# Altium schematic sheet Main Hull Power Board.SchDoc("Main Hull Power Board")
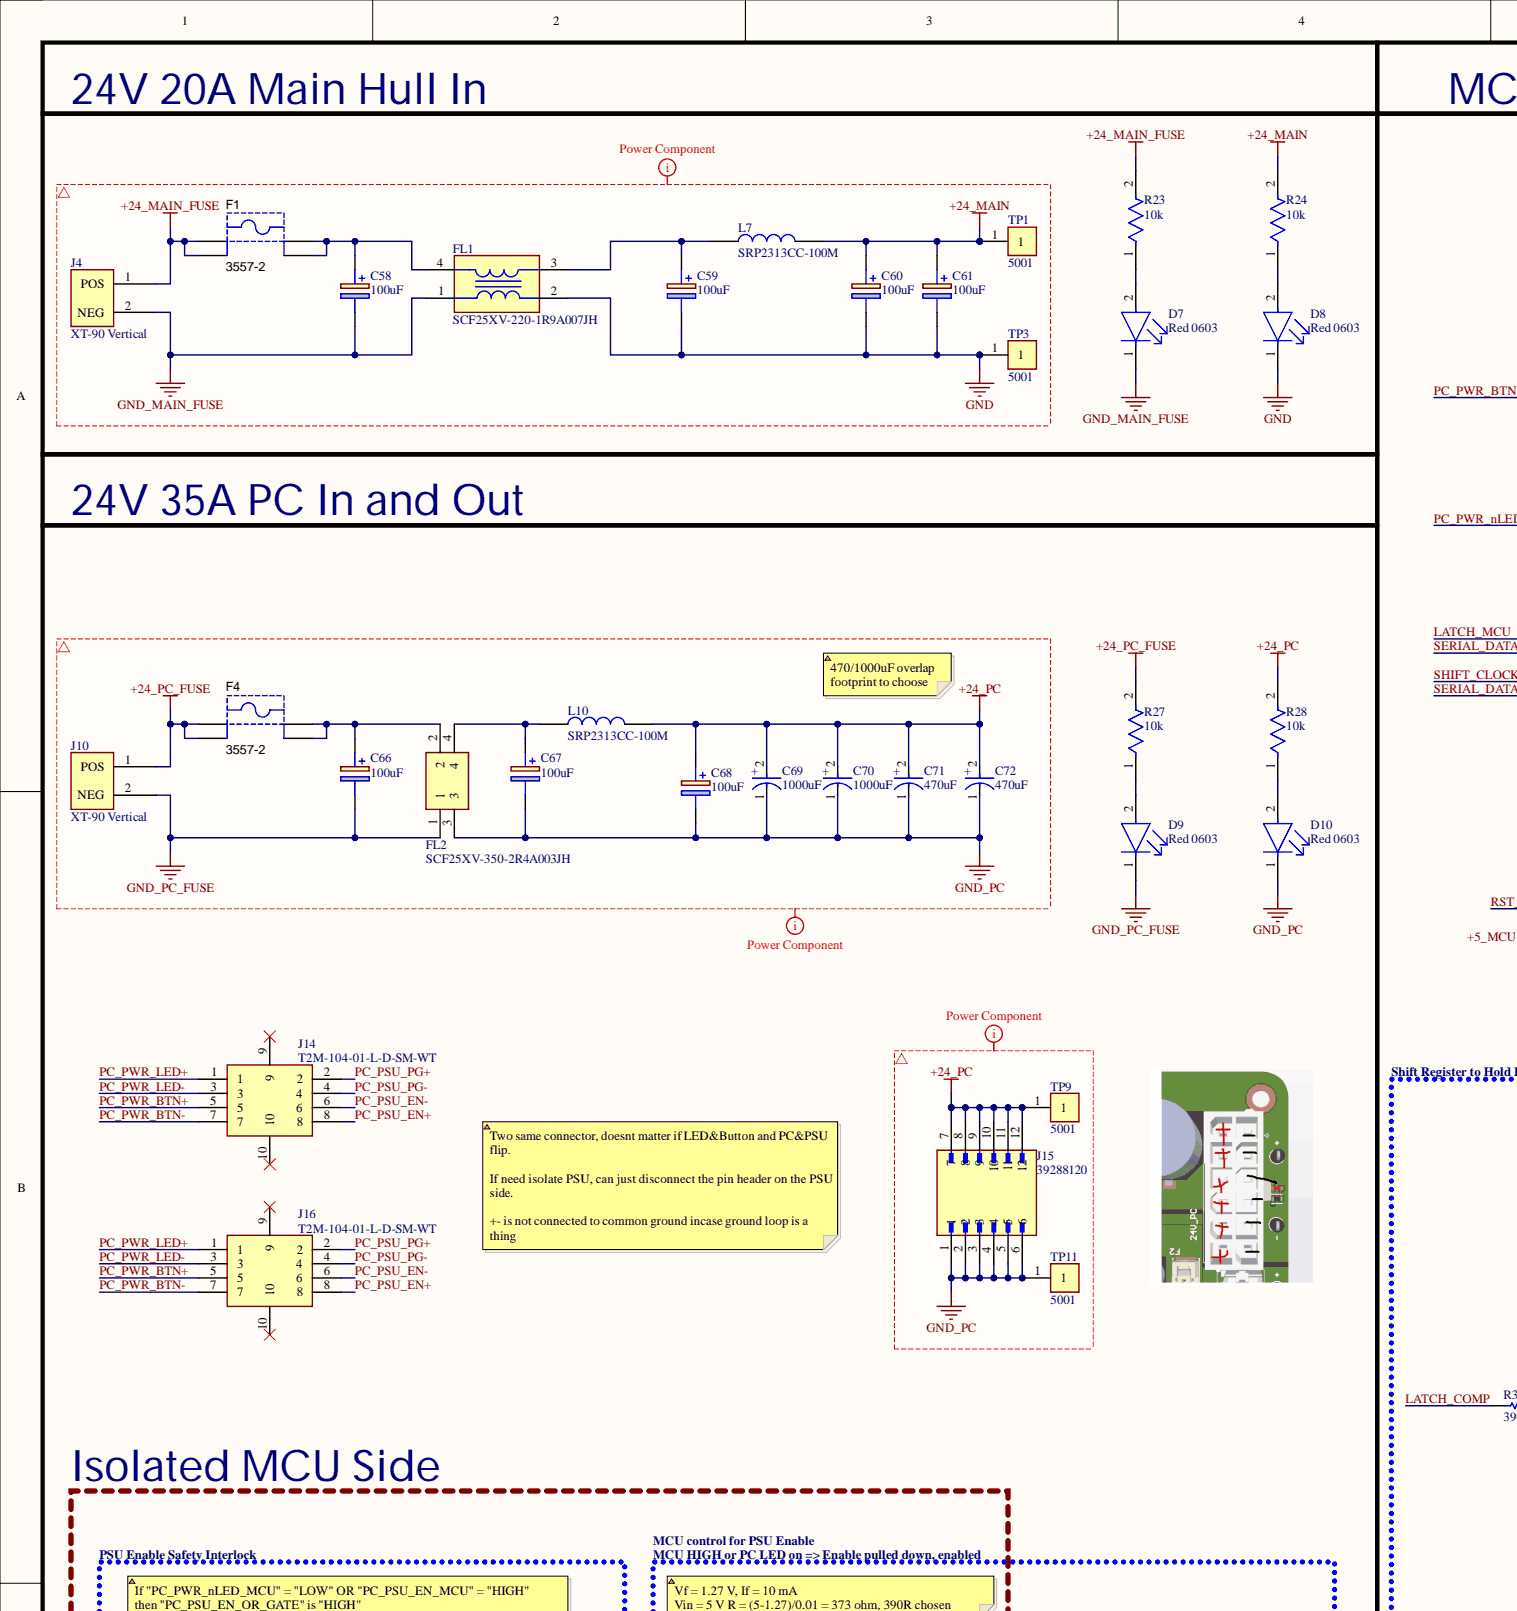
[diagram p0: Main Hull Power Board.SchDoc, top left]
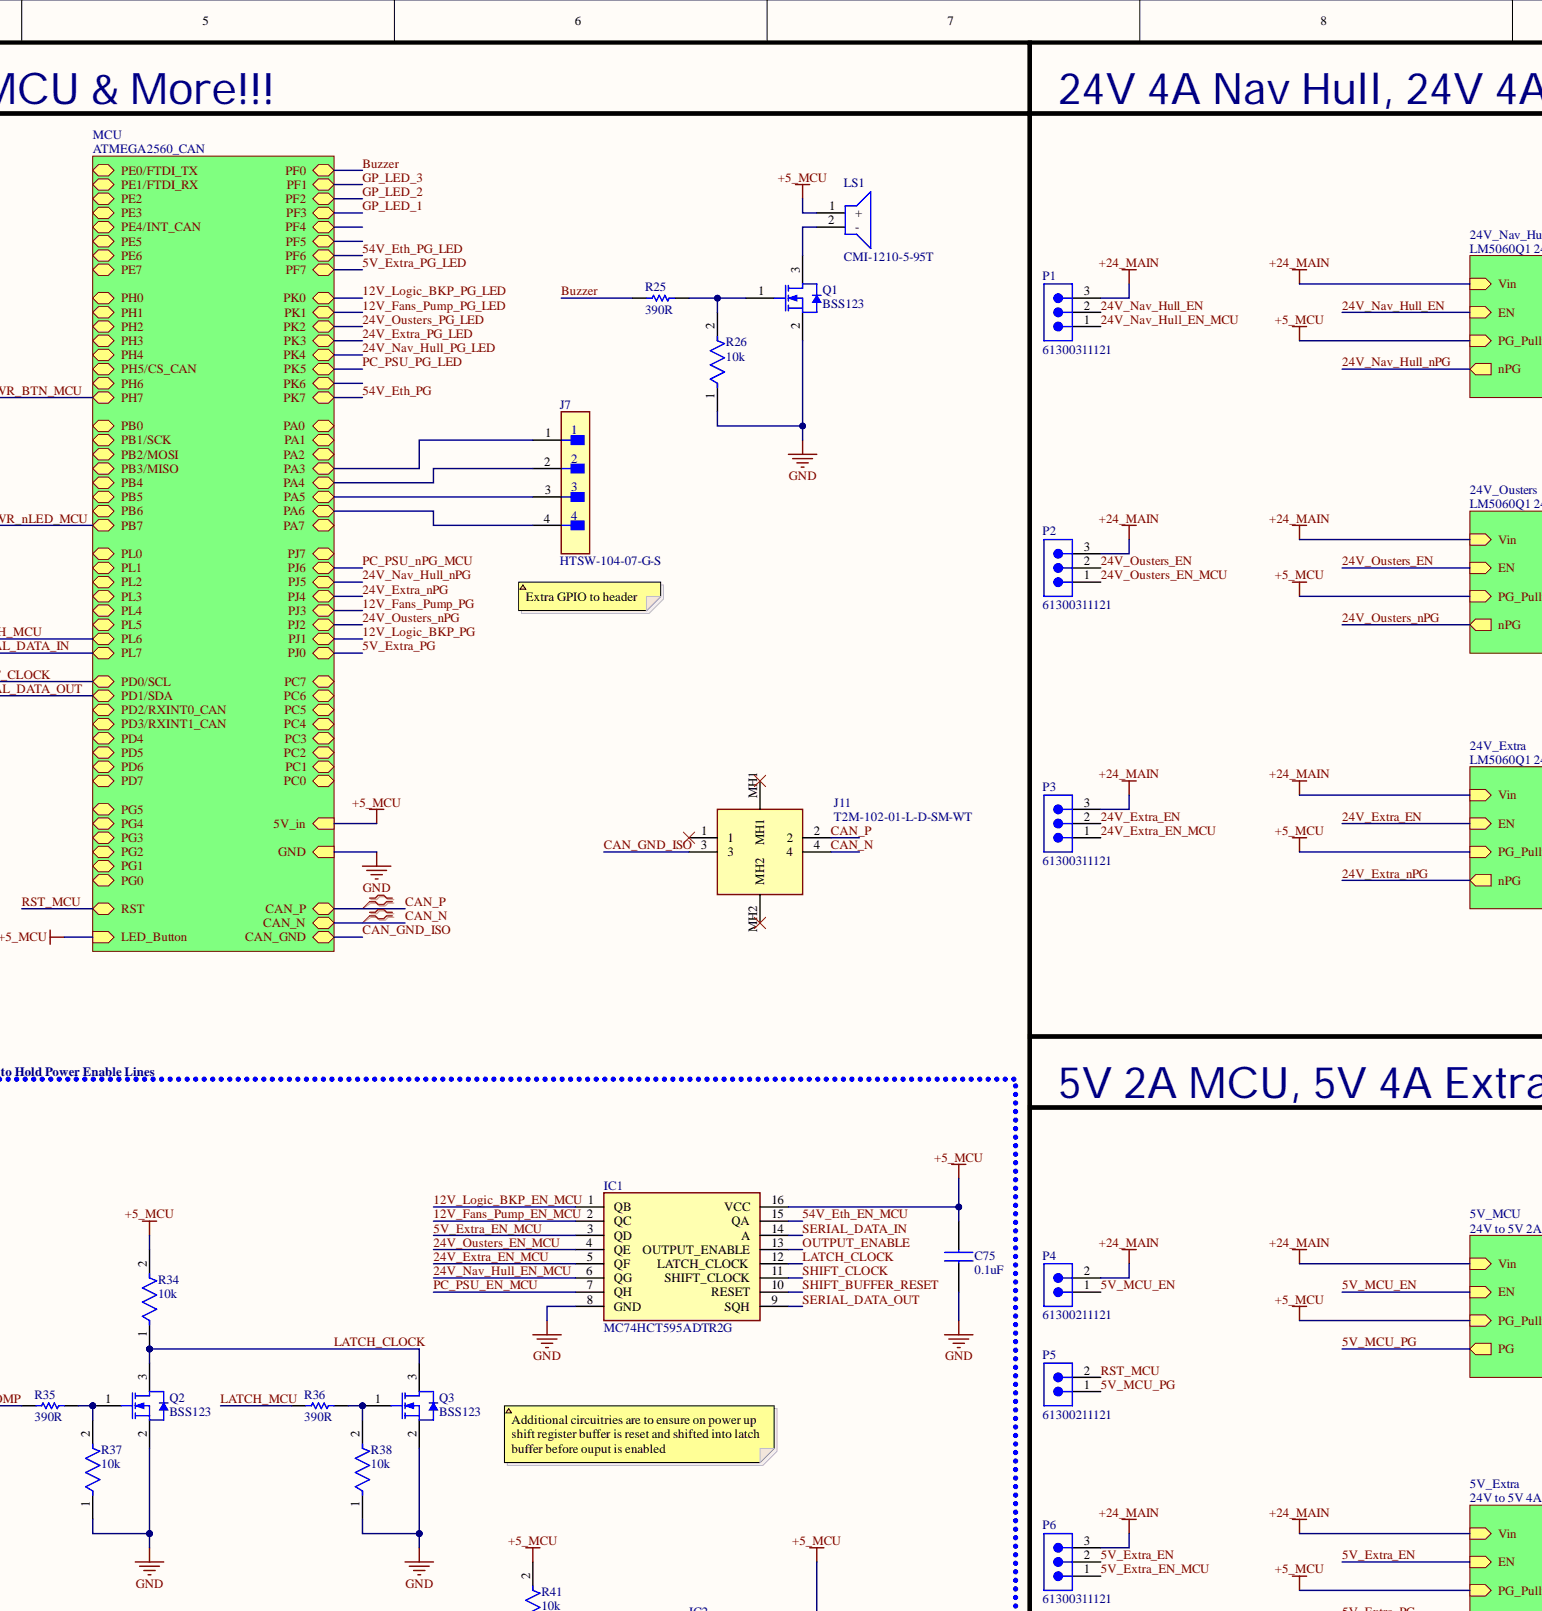
[diagram p1: Main Hull Power Board.SchDoc, top centre]
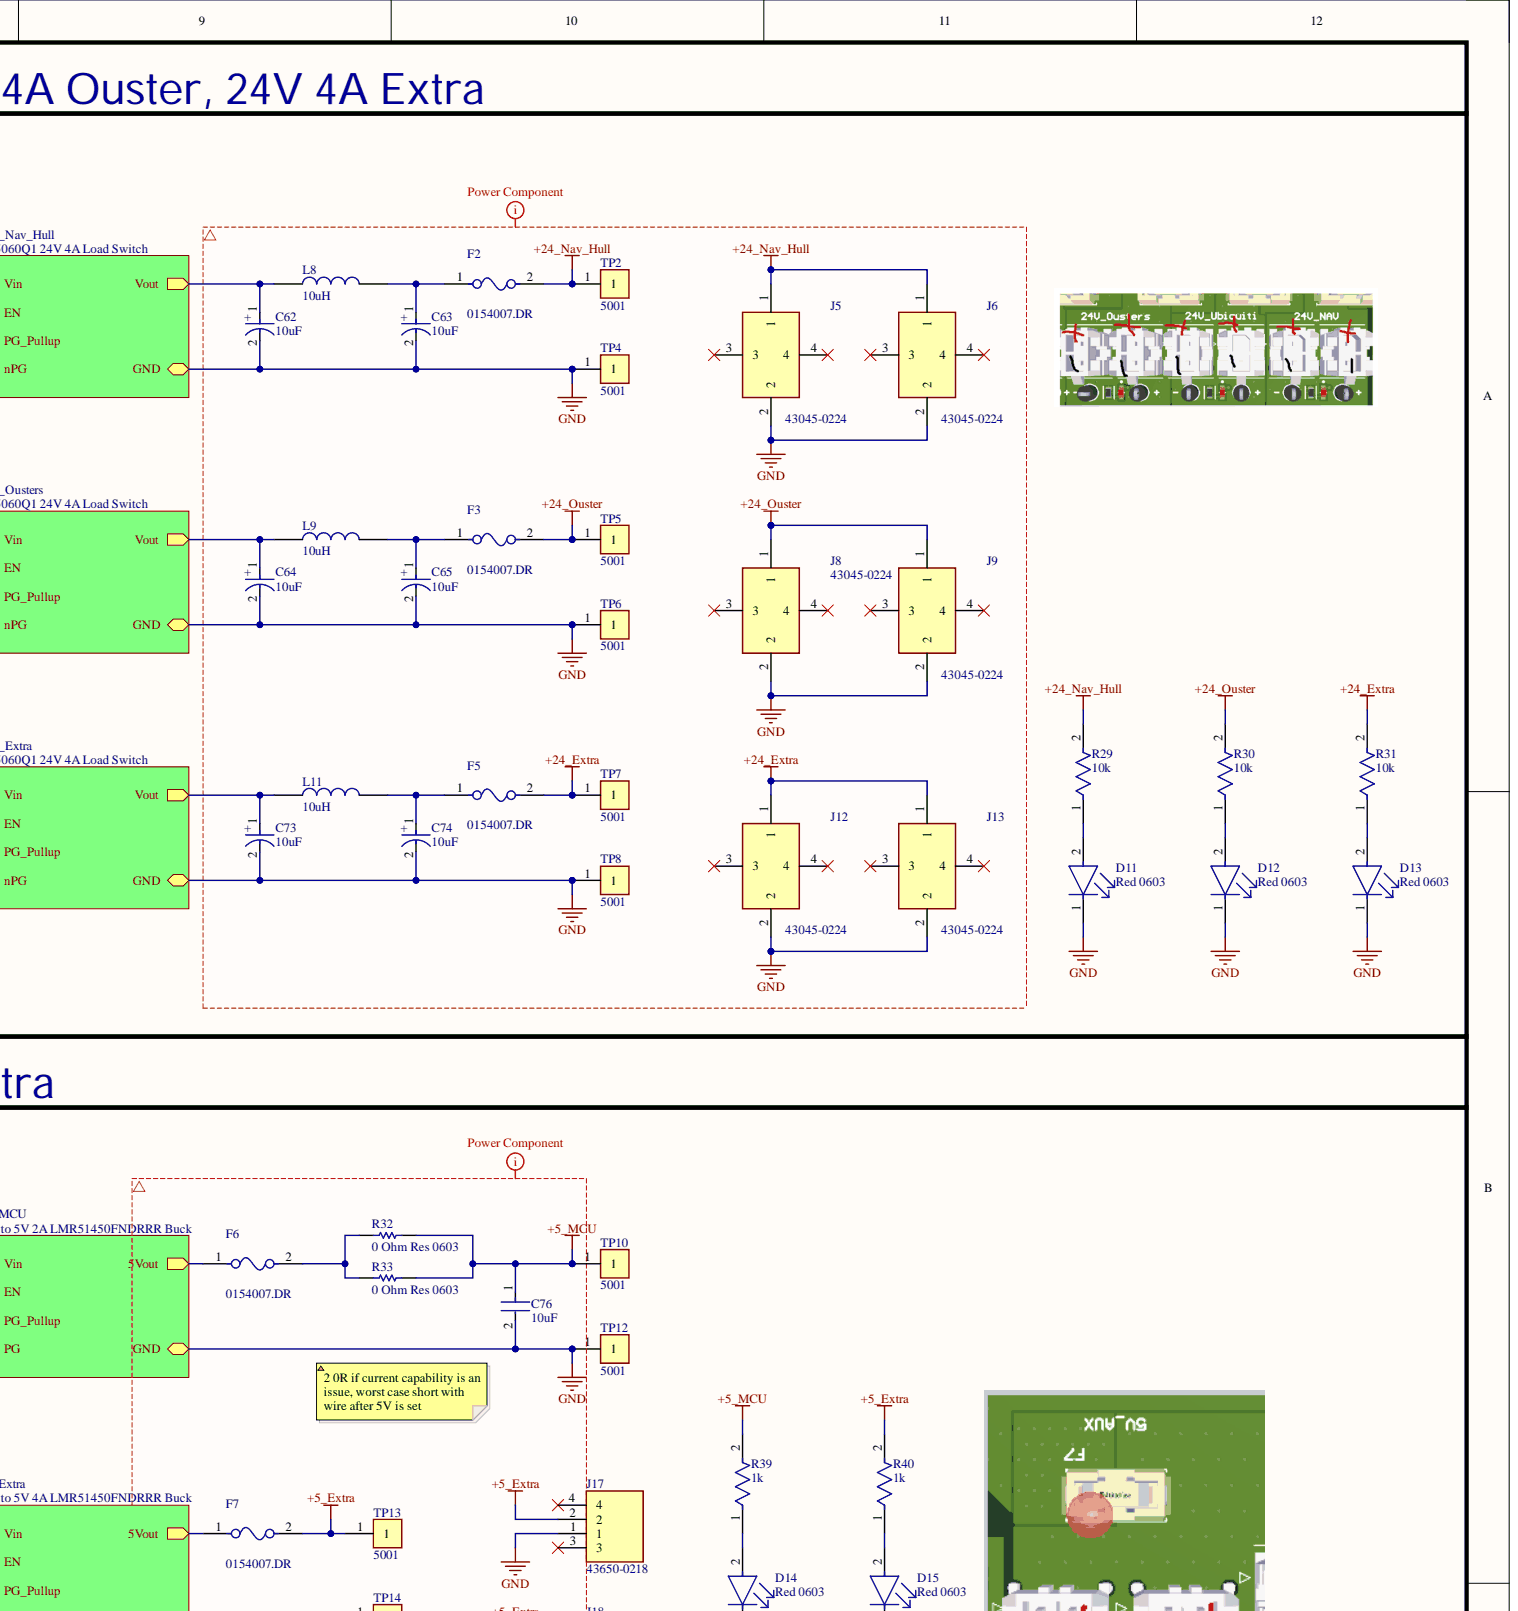
[diagram p2: Main Hull Power Board.SchDoc, top right]
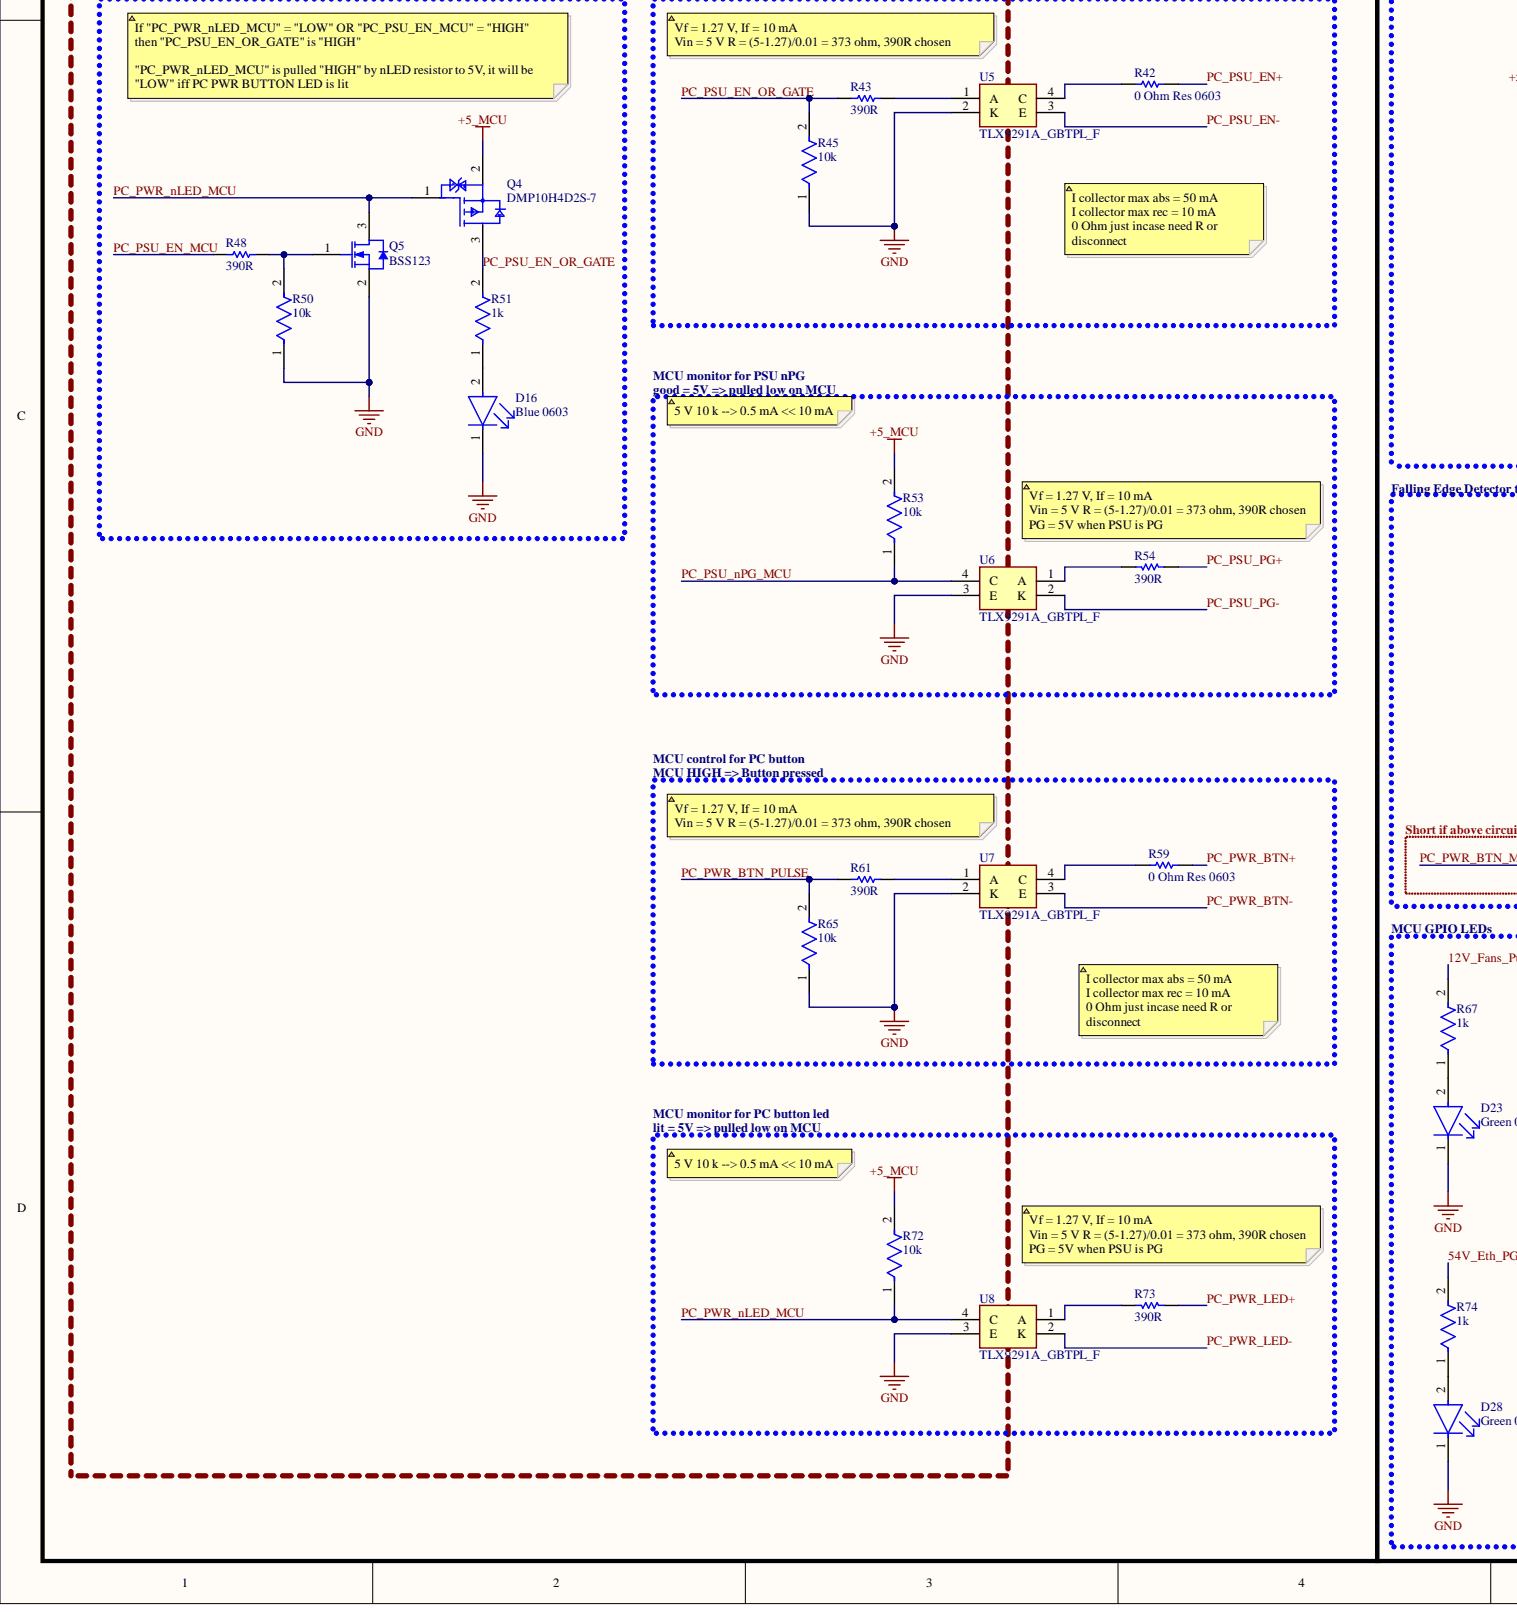
[diagram p3: Main Hull Power Board.SchDoc, bottom left]
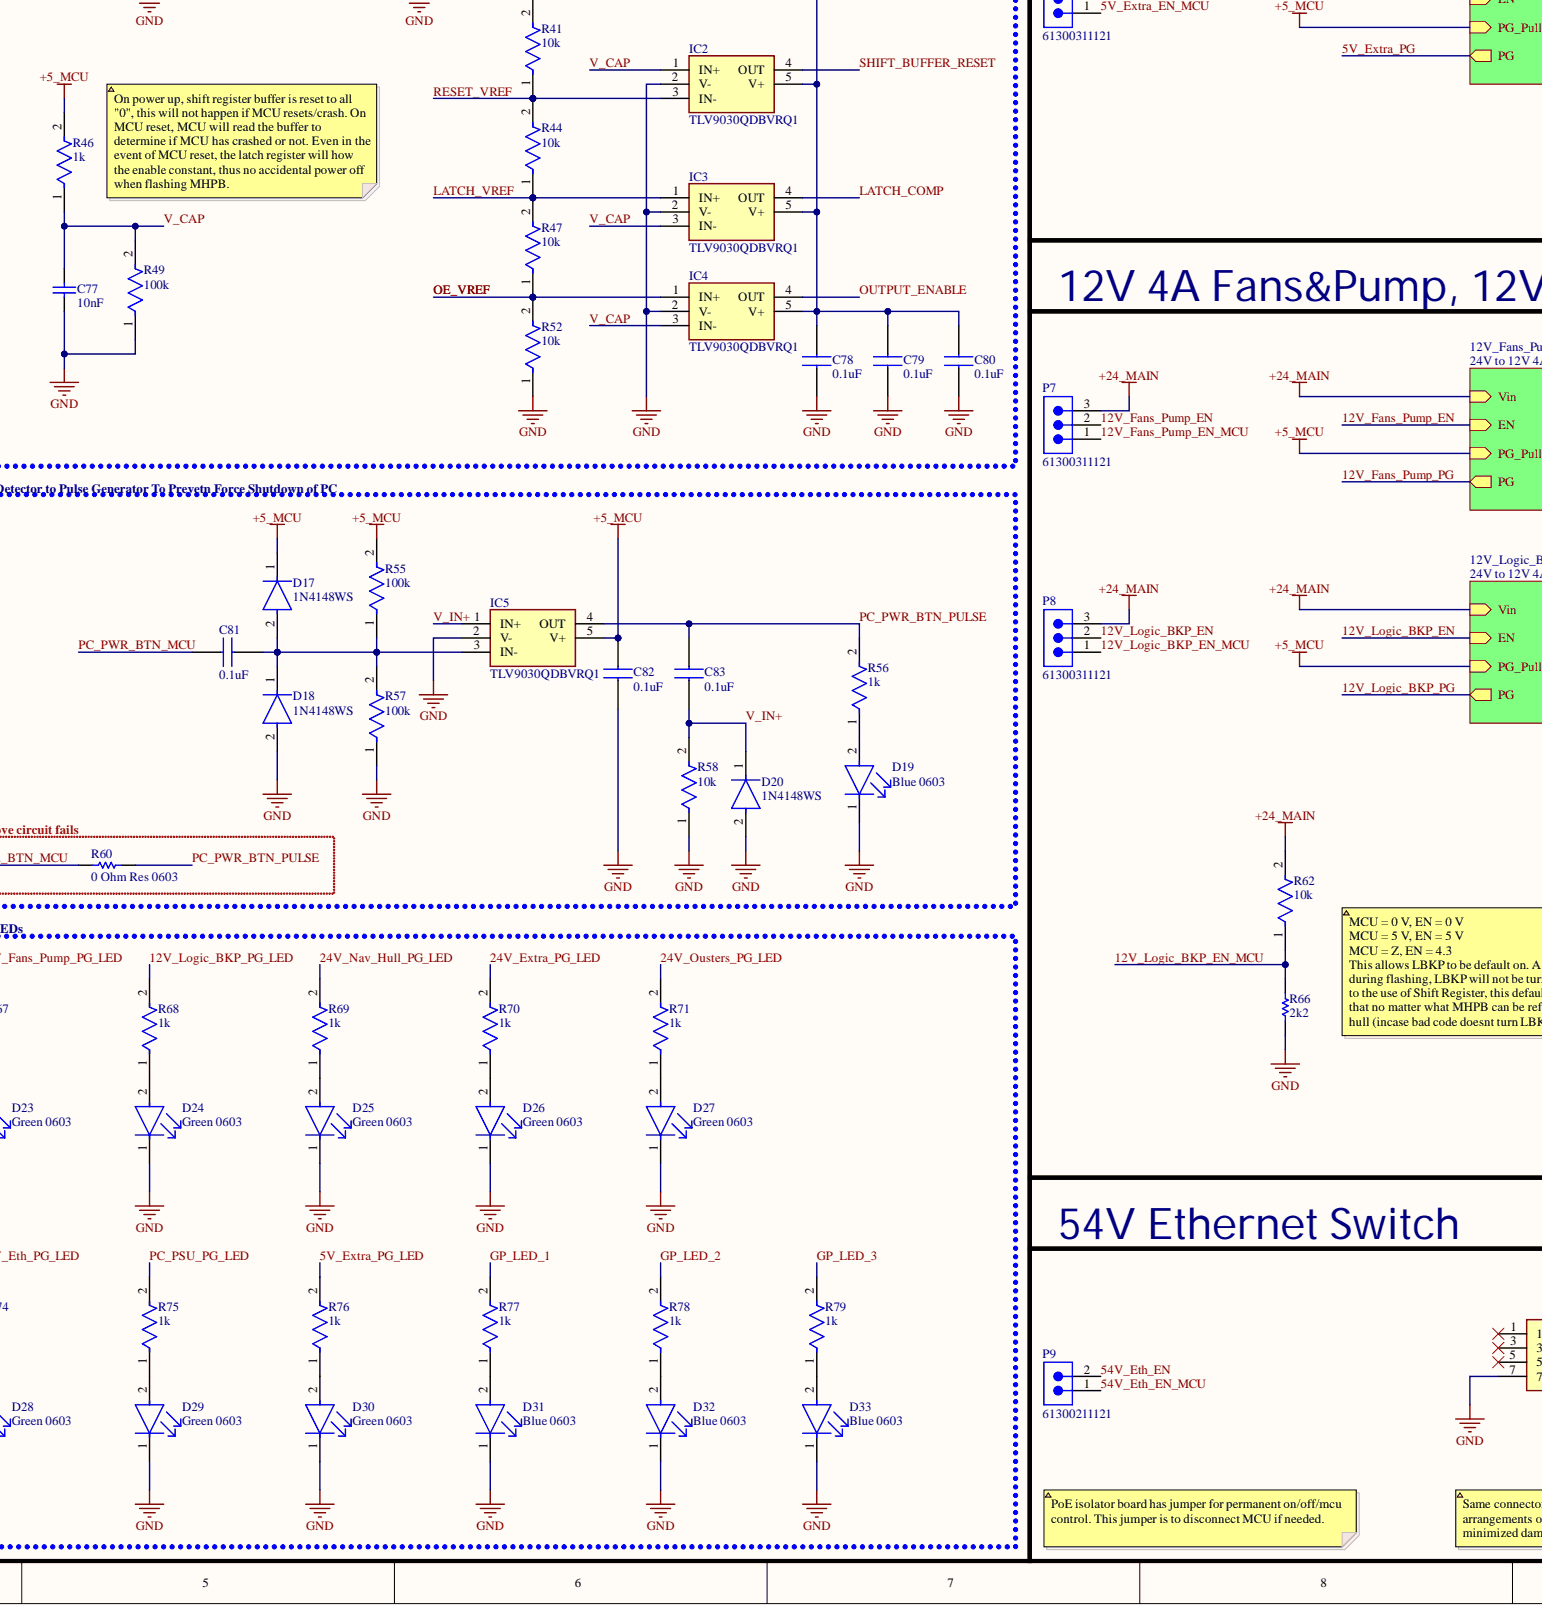
[diagram p4: Main Hull Power Board.SchDoc, bottom centre]
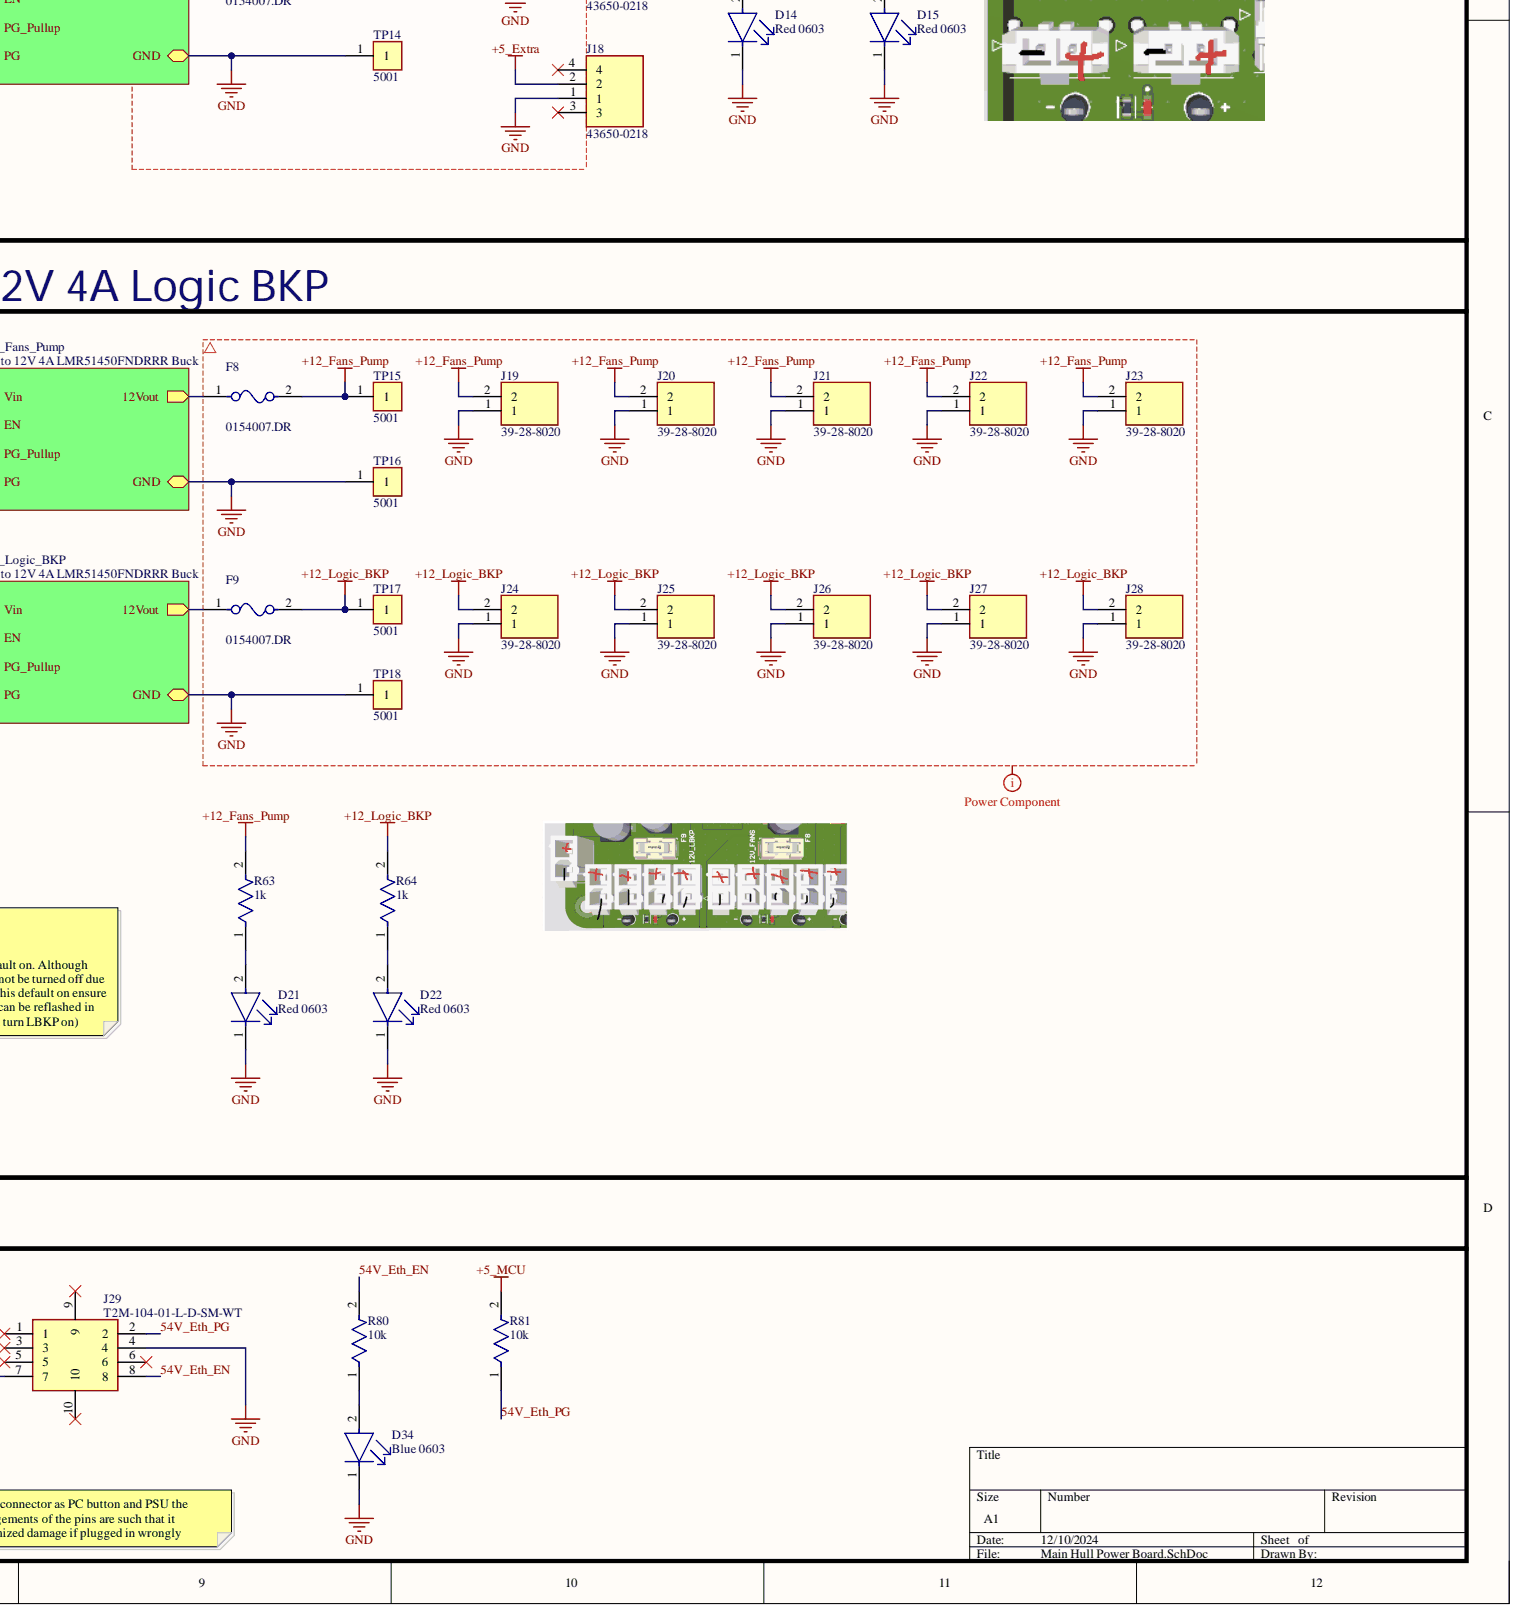
[diagram p5: Main Hull Power Board.SchDoc, bottom right]

## Parts
C58  100uF
C59  100uF
C60  100uF
C61  100uF
C62  10uF
C63  10uF
C64  10uF
C65  10uF
C66  100uF
C67  100uF
C68  100uF
C69  1000uF
C70  1000uF
C71  470uF
C72  470uF
C73  10uF
C74  10uF
C75  0.1uF
C76  10uF
C77  10nF
C78  0.1uF
C79  0.1uF
C80  0.1uF
C81  0.1uF
C82  0.1uF
C83  0.1uF
D7  Red 0603  Case Code (Imperial): 0603  Color: Red
D8  Red 0603  Case Code (Imperial): 0603  Color: Red
D9  Red 0603  Case Code (Imperial): 0603  Color: Red
D10  Red 0603  Case Code (Imperial): 0603  Color: Red
D11  Red 0603  Case Code (Imperial): 0603  Color: Red
D12  Red 0603  Case Code (Imperial): 0603  Color: Red
D13  Red 0603  Case Code (Imperial): 0603  Color: Red
D14  Red 0603  Case Code (Imperial): 0603  Color: Red
D15  Red 0603  Case Code (Imperial): 0603  Color: Red
D16  Blue 0603  Case Code (Imperial): 0603  Color: Blue
D17  1N4148WS
D18  1N4148WS
D19  Blue 0603  Case Code (Imperial): 0603  Color: Blue
D20  1N4148WS
D21  Red 0603  Case Code (Imperial): 0603  Color: Red
D22  Red 0603  Case Code (Imperial): 0603  Color: Red
D23  Green 0603  Case Code (Imperial): 0603  Color: Green
D24  Green 0603  Case Code (Imperial): 0603  Color: Green
D25  Green 0603  Case Code (Imperial): 0603  Color: Green
D26  Green 0603  Case Code (Imperial): 0603  Color: Green
D27  Green 0603  Case Code (Imperial): 0603  Color: Green
D28  Green 0603  Case Code (Imperial): 0603  Color: Green
D29  Green 0603  Case Code (Imperial): 0603  Color: Green
D30  Green 0603  Case Code (Imperial): 0603  Color: Green
D31  Blue 0603  Case Code (Imperial): 0603  Color: Blue
D32  Blue 0603  Case Code (Imperial): 0603  Color: Blue
D33  Blue 0603  Case Code (Imperial): 0603  Color: Blue
D34  Blue 0603  Case Code (Imperial): 0603  Color: Blue
F1  3557-2
F2  0154007.DR
F3  0154007.DR
F4  3557-2
F5  0154007.DR
F6  0154007.DR
F7  0154007.DR
F8  0154007.DR
F9  0154007.DR
FL1  SCF25XV-220-1R9A007JH
FL2  SCF25XV-350-2R4A003JH
IC1  MC74HCT595ADTR2G
IC2  TLV9030QDBVRQ1
IC3  TLV9030QDBVRQ1
IC4  TLV9030QDBVRQ1
IC5  TLV9030QDBVRQ1
J4  XT-90 Vertical
J5  43045-0224
J6  43045-0224
J7  HTSW-104-07-G-S
J8  43045-0224
J9  43045-0224
J10  XT-90 Vertical
J11  T2M-102-01-L-D-SM-WT
J12  43045-0224
J13  43045-0224
J14  T2M-104-01-L-D-SM-WT
J15  39288120
J16  T2M-104-01-L-D-SM-WT
J17  43650-0218
J18  43650-0218
J19  39-28-8020
J20  39-28-8020
J21  39-28-8020
J22  39-28-8020
J23  39-28-8020
J24  39-28-8020
J25  39-28-8020
J26  39-28-8020
J27  39-28-8020
J28  39-28-8020
J29  T2M-104-01-L-D-SM-WT
L7  SRP2313CC-100M
L8  10uH
L9  10uH
L10  SRP2313CC-100M
L11  10uH
LS1  CMI-1210-5-95T
P1  61300311121
P2  61300311121
P3  61300311121
P4  61300211121
P5  61300211121
P6  61300311121
P7  61300311121
P8  61300311121
P9  61300211121
Q1  BSS123
Q2  BSS123
Q3  BSS123
Q4  DMP10H4D2S-7
Q5  BSS123
R23  10k
R24  10k
R25  390R
R26  10k
R27  10k
R28  10k
R29  10k
R30  10k
R31  10k
R32  0 Ohm Res 0603  Case Code (Imperial): 0603
R33  0 Ohm Res 0603  Case Code (Imperial): 0603
R34  10k
R35  390R
R36  390R
R37  10k
R38  10k
R39  1k
R40  1k
R41  10k
R42  0 Ohm Res 0603  Case Code (Imperial): 0603
R43  390R
R44  10k
R45  10k
R46  1k
R47  10k
R48  390R
R49  100k
R50  10k
R51  1k
R52  10k
R53  10k
R54  390R
R55  100k
R56  1k
R57  100k
R58  10k
R59  0 Ohm Res 0603  Case Code (Imperial): 0603
R60  0 Ohm Res 0603  Case Code (Imperial): 0603
R61  390R
R62  10k
R63  1k
R64  1k
R65  10k
R66  2k2
R67  1k
R68  1k
R69  1k
R70  1k
R71  1k
R72  10k
R73  390R
R74  1k
R75  1k
R76  1k
R77  1k
R78  1k
R79  1k
R80  10k
R81  10k
TP1  5001
TP2  5001
TP3  5001
TP4  5001
TP5  5001
TP6  5001
TP7  5001
TP8  5001
TP9  5001
TP10  5001
TP11  5001
TP12  5001
TP13  5001
TP14  5001
TP15  5001
TP16  5001
TP17  5001
TP18  5001
U5  TLX9291A_GBTPL_F
U6  TLX9291A_GBTPL_F
U7  TLX9291A_GBTPL_F
U8  TLX9291A_GBTPL_F

## Nets
GND: C59.2 C60.2 C61.2 C62.2 C63.2 C64.2 C65.2 C73.2 C74.2 C75.1 C76.2 C77.1 C78.2 C79.2 C80.2 C82.2 D8.1 D11.1 D12.1 D13.1 D14.1 D15.1 D16.1 D18.2 D19.1 D20.2 D21.1 D22.1 D23.1 D24.1 D25.1 D26.1 D27.1 D28.1 D29.1 D30.1 D31.1 D32.1 D33.1 D34.1 FL1.2 IC1.8(GND) IC2.2(V-) IC3.2(V-) IC4.2(V-) IC5.2(V-) J5.2 J6.2 J8.2 J9.2 J12.2 J13.2 J17.1 J18.1 J19.1 J20.1 J21.1 J22.1 J23.1 J24.1 J25.1 J26.1 J27.1 J28.1 J29.4 J29.7 Q1.2 Q2.2 Q3.2 Q5.2 R26.1 R37.1 R38.1 R45.1 R49.1 R50.1 R52.1 R57.1 R58.1 R65.1 R66.1 TP3.1 TP4.1 TP6.1 TP8.1 TP12.1 TP14.1 TP16.1 TP18.1 U5.2(K) U6.3(E) U7.2(K) U8.3(E)
GND_MAIN_FUSE: C58.2 D7.1 FL1.1 J4.2
GND_PC: C67.2 C68.2 C69.1 C70.1 C71.1 C72.1 D10.1 FL2.3 J15.1 J15.2 J15.3 J15.4 J15.5 J15.6 TP11.1
GND_PC_FUSE: C66.2 D9.1 FL2.1 J10.2
5V_Extra_EN: C75.2 C76.1 C78.1 C79.1 C80.1 C82.1 D17.1 F7.2 IC1.16(VCC) IC2.5(V+) IC3.5(V+) IC4.5(V+) IC5.5(V+) J17.2 J18.2 LS1.1 P6.2 Q4.2 R32.2 R33.2 R34.2 R39.2 R40.2 R41.2 R46.2 R53.2 R55.2 R72.2 R81.2 TP10.1 TP13.1
5V_Extra_EN_MCU: IC1.3(QD) P6.1
5V_Extra_PG_LED: R76.2
5V_MCU_EN: P4.1
5V_MCU_PG: P5.1
12V_Fans_Pump_EN: F8.2 F9.2 J19.2 J20.2 J21.2 J22.2 J23.2 J24.2 J25.2 J26.2 J27.2 J28.2 P7.2 R63.2 R64.2 TP15.1 TP17.1
12V_Fans_Pump_EN_MCU: IC1.2(QC) P7.1
12V_Fans_Pump_PG_LED: R67.2
12V_Logic_BKP_EN: P8.2
12V_Logic_BKP_EN_MCU: IC1.1(QB) P8.1 R62.1 R66.2
12V_Logic_BKP_PG_LED: R68.2
24V_Extra_EN: C60.1 C61.1 C68.1 C69.2 C70.2 C71.2 C72.2 F1.1 F1.2 F2.2 F3.2 F4.1 F4.2 F5.2 J4.1 J5.1 J6.1 J8.1 J9.1 J10.1 J12.1 J13.1 J15.7 J15.8 J15.9 J15.10 J15.11 J15.12 L7.2 L10.2 P1.3 P2.3 P3.2 P3.3 P4.2 P6.3 P7.3 P8.3 R23.2 R24.2 R27.2 R28.2 R29.2 R30.2 R31.2 R62.2 TP1.1 TP2.1 TP5.1 TP7.1 TP9.1
24V_Extra_EN_MCU: IC1.5(QF) P3.1
24V_Extra_PG_LED: R70.2
24V_Nav_Hull_EN: P1.2
24V_Nav_Hull_EN_MCU: IC1.6(QG) P1.1
24V_Nav_Hull_PG_LED: R69.2
24V_Ousters_EN: P2.2
24V_Ousters_EN_MCU: IC1.4(QE) P2.1
24V_Ousters_PG_LED: R71.2
54V_Eth_EN: J29.8 P9.2 R80.2
54V_Eth_EN_MCU: IC1.15(QA) P9.1
54V_Eth_PG: J29.2 R81.1
54V_Eth_PG_LED: R74.2
Buzzer: R25.2
CAN_GND_ISO: J11.3
CAN_N: J11.4
CAN_P: J11.2
GP_LED_1: R77.2
GP_LED_2: R78.2
GP_LED_3: R79.2
LATCH_CLOCK: IC1.12(LATCH_CLOCK) Q2.3 Q3.3 R34.1
LATCH_COMP: IC3.4(OUT) R35.2
LATCH_MCU: R36.2
LATCH_VREF: IC3.1(IN+) R44.1 R47.2
OE_VREF: IC4.1(IN+) R47.1 R52.2
OUTPUT_ENABLE: IC1.13(OUTPUT_ENABLE) IC4.4(OUT)
PC_PSU_EN+: J14.8 J16.8 R42.1
PC_PSU_EN-: J14.6 J16.6 U5.3(E)
PC_PSU_EN_MCU: IC1.7(QH) R48.2
PC_PSU_EN_OR_GATE: Q4.3 R43.2 R45.2 R51.2
PC_PSU_nPG_MCU: R53.1 U6.4(C)
PC_PSU_PG+: J14.2 J16.2 R54.2
PC_PSU_PG-: J14.4 J16.4 U6.2(K)
PC_PSU_PG_LED: R75.2
PC_PWR_BTN+: J14.5 J16.5 R59.2
PC_PWR_BTN-: J14.7 J16.7 U7.3(E)
PC_PWR_BTN_MCU: C81.2 R60.1
PC_PWR_BTN_PULSE: C83.1 IC5.4(OUT) R56.2 R60.2 R61.2 R65.2
PC_PWR_LED+: J14.1 J16.1 R73.2
PC_PWR_LED-: J14.3 J16.3 U8.2(K)
PC_PWR_nLED_MCU: Q4.1 Q5.3 R72.1 U8.4(C)
RESET_VREF: IC2.3(IN-) R41.1 R44.2
RST_MCU: P5.2
SERIAL_DATA_IN: IC1.14(A)
SERIAL_DATA_OUT: IC1.9(SQH)
SHIFT_BUFFER_RESET: IC1.10(RESET) IC2.4(OUT)
SHIFT_CLOCK: IC1.11(SHIFT_CLOCK)
V_CAP: C77.2 IC2.1(IN+) IC3.3(IN-) IC4.3(IN-) R46.1 R49.2
V_IN+: C83.2 D20.1 IC5.1(IN+) R58.2
NetC58_1: C58.1 F1.3 F1.4 FL1.4
NetC59_1: C59.1 FL1.3 L7.1
NetC62_1: C62.1 L8.1
NetC63_1: C63.1 F2.1 L8.2
NetC64_1: C64.1 L9.1
NetC65_1: C65.1 F3.1 L9.2
NetC66_1: C66.1 F4.3 F4.4 FL2.2
NetC67_1: C67.1 FL2.4 L10.1
NetC73_1: C73.1 L11.1
NetC74_1: C74.1 F5.1 L11.2
NetC81_1: C81.1 D17.2 D18.1 IC5.3(IN-) R55.1 R57.2
NetD7_2: D7.2 R23.1
NetD8_2: D8.2 R24.1
NetD9_2: D9.2 R27.1
NetD10_2: D10.2 R28.1
NetD11_2: D11.2 R29.1
NetD12_2: D12.2 R30.1
NetD13_2: D13.2 R31.1
NetD14_2: D14.2 R39.1
NetD15_2: D15.2 R40.1
NetD16_2: D16.2 R51.1
NetD19_2: D19.2 R56.1
NetD21_2: D21.2 R63.1
NetD22_2: D22.2 R64.1
NetD23_2: D23.2 R67.1
NetD24_2: D24.2 R68.1
NetD25_2: D25.2 R69.1
NetD26_2: D26.2 R70.1
NetD27_2: D27.2 R71.1
NetD28_2: D28.2 R74.1
NetD29_2: D29.2 R75.1
NetD30_2: D30.2 R76.1
NetD31_2: D31.2 R77.1
NetD32_2: D32.2 R78.1
NetD33_2: D33.2 R79.1
NetD34_2: D34.2 R80.1
NetF6_1: F6.1
NetF6_2: F6.2 R32.1 R33.1
NetF7_1: F7.1
NetF8_1: F8.1
NetF9_1: F9.1
NetJ5_3: J5.3
NetJ5_4: J5.4
NetJ6_3: J6.3
NetJ6_4: J6.4
NetJ7_1: J7.1
NetJ7_2: J7.2
NetJ7_3: J7.3
NetJ7_4: J7.4
NetJ8_3: J8.3
NetJ8_4: J8.4
NetJ9_3: J9.3
NetJ9_4: J9.4
NetJ11_1: J11.1
NetJ11_MH1: J11.MH1
NetJ11_MH2: J11.MH2
NetJ12_3: J12.3
NetJ12_4: J12.4
NetJ13_3: J13.3
NetJ13_4: J13.4
NetJ14_9: J14.9
NetJ14_10: J14.10
NetJ16_9: J16.9
NetJ16_10: J16.10
NetJ17_3: J17.3
NetJ17_4: J17.4
NetJ18_3: J18.3
NetJ18_4: J18.4
NetJ29_1: J29.1
NetJ29_3: J29.3
NetJ29_5: J29.5
NetJ29_6: J29.6
NetJ29_9: J29.9
NetJ29_10: J29.10
NetLS1_2: LS1.2 Q1.3
NetQ1_1: Q1.1 R25.1 R26.2
NetQ2_1: Q2.1 R35.1 R37.2
NetQ3_1: Q3.1 R36.1 R38.2
NetQ5_1: Q5.1 R48.1 R50.2
NetR42_2: R42.2 U5.4(C)
NetR43_1: R43.1 U5.1(A)
NetR54_1: R54.1 U6.1(A)
NetR59_1: R59.1 U7.4(C)
NetR61_1: R61.1 U7.1(A)
NetR73_1: R73.1 U8.1(A)
5V_Extra_PG:
12V_Fans_Pump_PG:
12V_Logic_BKP_PG:
24V_Extra_nPG:
24V_Nav_Hull_nPG:
24V_Ousters_nPG:
PA0:
PA1:
PA2:
PA7:
PB0:
PB1/SCK:
PB2/MOSI:
PB3/MISO:
PB4:
PB5:
PB6:
PC0:
PC1:
PC2:
PC3:
PC4:
PC5:
PC6:
PC7:
PD2/RXINT0_CAN:
PD3/RXINT1_CAN:
PD4:
PD5:
PD6:
PD7:
PE0/FTDI_TX:
PE1/FTDI_RX:
PE2:
PE3:
PE4/INT_CAN:
PE5:
PE6:
PE7:
PF4:
PF5:
PG0:
PG1:
PG2:
PG3:
PG4:
PG5:
PH0:
PH1:
PH2:
PH3:
PH4:
PH5/CS_CAN:
PH6:
PJ7:
PK6:
PL0:
PL1:
PL2:
PL3:
PL4:
PL5:
